# Altium schematic sheet StepMotor2.SchDoc
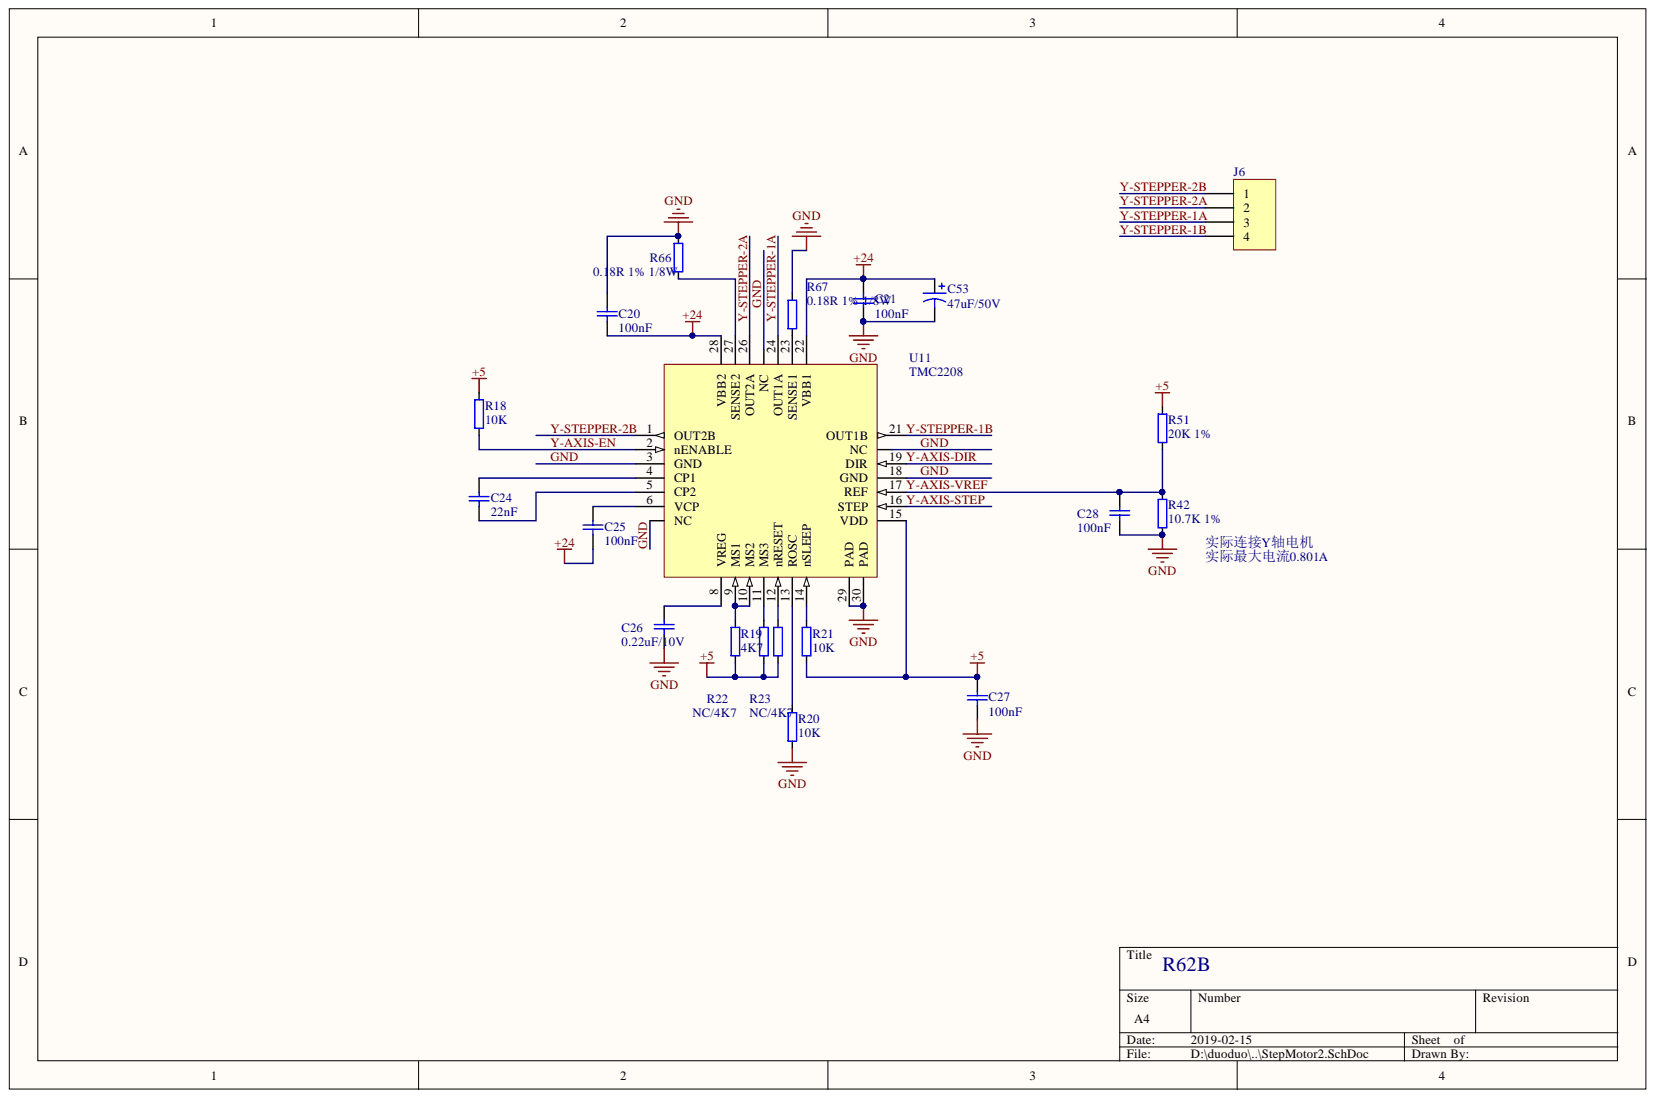
[diagram p0: StepMotor2.SchDoc]

## Parts
C20  100nF  [0603]
C21  100nF  [0603]
C24  22nF  [0603]
C25  100nF  [0603]
C26  0.22uF/10V  [0603]
C27  100nF  [0603]
C28  100nF  [0603]
C53  47uF/50V  [EC-6.3]
J6  Header 4  [XH2.54-4-A]  (value not drawn)
R18  10K  [0603]
R19  4K7  [0603]
R20  10K  [0603]
R21  10K  [0603]
R22  NC/4K7  [0603]
R23  NC/4K7  [0603]
R42  10.7K 1%  [0603]
R51  20K 1%  [0603]
R66  0.18R 1% 1/8W  [0805]
R67  0.18R 1% 1/8W  [0805]
U11  TMC2208  [QFN-28]

## Nets
+5: C27.1 R18.2 R19.2 R21.2 R22.2 R23.2 R51.2 U11.15(VDD)
+24: C20.2 C21.2 C25.2 C53.1 U11.22(VBB1) U11.28(VBB2)
GND: C20.1 C21.1 C26.2 C27.2 C28.2 C53.2 R20.2 R42.2 R66.2 R67.2 U11.3(GND) U11.7(NC) U11.18(GND) U11.20(NC) U11.25(NC) U11.29(PAD) U11.30(PAD)
Y-AXIS-DIR: U11.19(DIR)
Y-AXIS-EN: R18.1 U11.2(nENABLE)
Y-AXIS-STEP: U11.16(STEP)
Y-AXIS-VREF: C28.1 R42.1 R51.1 U11.17(REF)
Y-STEPPER-1A: J6.3 U11.24(OUT1A)
Y-STEPPER-1B: J6.4 U11.21(OUT1B)
Y-STEPPER-2A: J6.2 U11.26(OUT2A)
Y-STEPPER-2B: J6.1 U11.1(OUT2B)
NetC24_1: C24.1 U11.4(CP1)
NetC24_2: C24.2 U11.5(CP2)
NetC25_1: C25.1 U11.6(VCP)
NetC26_1: C26.1 U11.8(VREG)
NetR19_1: R19.1 U11.9(MS1) U11.10(MS2)
NetR20_1: R20.1 U11.13(ROSC)
NetR21_1: R21.1 U11.14(nSLEEP)
NetR22_1: R22.1 U11.11(MS3)
NetR23_1: R23.1 U11.12(nRESET)
NetR66_1: R66.1 U11.27(SENSE2)
NetR67_1: R67.1 U11.23(SENSE1)

## Library data of the parts
C20 C21 C24-C28 = CAP: Capacitor; Component Kind: Standard; Library Name: DMSch.SCHLIB
C53 = Cap Pol1: Polarized Capacitor (Radial); Component Kind: Standard; Library Name: Miscellaneous Devices.IntLib; Signal Integrity: Cap Pol; Simulation: CAP
J6 = Header 4: Header, 4-Pin; Component Kind: Standard; LatestRevisionDate: 17-Jul-2002; LatestRevisionNote: Re-released for DXP Platform.; Library Name: Miscellaneous Connectors.IntLib; Signal Integrity: Connector; Publisher: Altium Limited
R18-R23 R42 R51 R66 R67 = RES3: Component Kind: Standard; Library Name: DMSch.SCHLIB
U11 = A4988-QFN28: A4982 Step Driver; Component Kind: Standard; Library Name: DMSch.SCHLIB
